# Altium schematic sheet CANFD.SchDoc
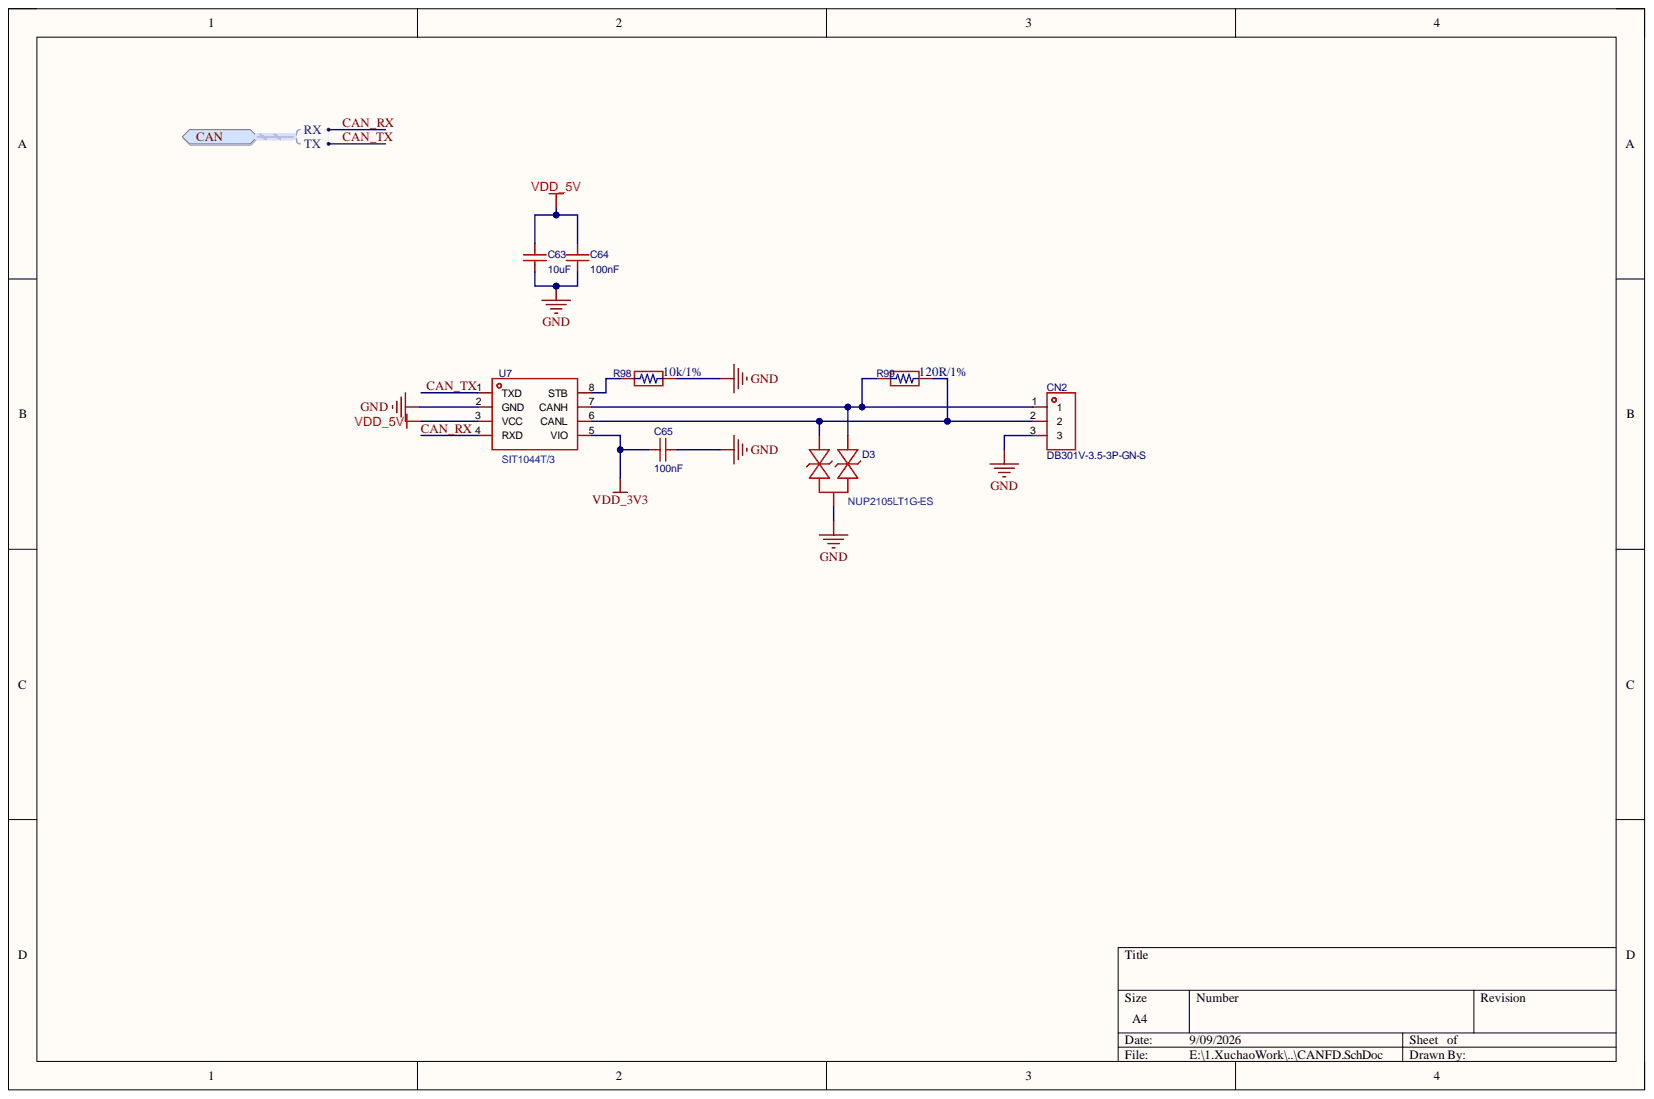
[diagram p0: CANFD.SchDoc]

## Parts
C63  10uF  [C0402]
C64  100nF  [C0402]
C65  100nF  [C0402]
CN2  DB301V-3.5-3P-GN-S  [CONN-TH_DB301V-3.5-3P-GN]
D3  NUP2105LT1G-ES  [SOT-23-3_L2.9-W1.3-P0.95-LS2.4-BR]
R98  10k/1%  [R0402]
R99  120R/1%  [R0603]
U7  SIT1044T/3  [SOP-8_L4.9-W3.9-P1.27-LS6.0-BL]

## Ports
CAN, CAN.RX, CAN.TX

## Nets
GND: C63.1 C64.1 C65.1 CN2.3 D3.3 R98.1 U7.2(GND)
VDD_3V3: C65.2 U7.5(VIO)
VDD_5V: C63.2 C64.2 U7.3(VCC)
CAN_RX: U7.4(RXD) ; port CAN
CAN_TX: U7.1(TXD) ; port CAN
NetCN2_1: CN2.1 D3.1 R99.2 U7.7(CANH)
NetCN2_2: CN2.2 D3.2 R99.1 U7.6(CANL)
NetR98_2: R98.2 U7.8(STB)

## Library data of the parts
C63-C65 = 0SMD_CAP: Symbol: CL10A106KP8NNNC; Component Kind: Standard; Library Name: CYKJ_LibPkg.IntLib
CN2 = CONN_DB301V-3.5-3P-GN-S: 结构:1x3P;间距:3.5mm;颜色:绿色;安装方式:直插;排数:1;每排PIN数:3;额定电流:7A;额定电压:300V;线规 - AWG:18~24;线规 - 平方毫米:0.5~1;工作温度:-40℃~+105℃;; Manufacturer: DORABO(地博电气); AddInBom: yes; Current Rating: 7A; ModelId: b68b144a19374becb19b2877333498c7聨0819f05c4eef4c71ace90d822a990e87; ModelTransform: 452.755,255.905,0,0,0,0,-0.005,5.906,-137.795; Number of PINs Per Row: 3; Number of Positions or Pins: 1x3P; Pitch: 3.5mm; Screw Specification: M2; Voltage Rating (Max): 300V; Wire Gauge - AWG: 18~24; Wire Gauge - mm2: 0.5~1; Color: 绿色; Component Kind: Standard; ConvertToPcb: yes; Datasheet: https://item.szlcsc.com/datasheet/DB301V-3.5-3P-GN-S/728966.html; Device: DB301V-3.5-3P-GN-S; FootprintName: CONN-TH_DB301V-3.5-3P-GN; JLCPCB Part Class: Extended Part; LCSC Part Name: 1x3P 3.5mm 直插 排数:1 每排P数:3; ManufacturerPart: DB301V-3.5-3P-GN-S; ModelName: CONN-TH_DB301V-3.5-3P-GN; Mounting Type: 直插; Number of Pins: 3P; Number of Rows: 1; Operating Temperature: -40℃~+105℃; Supplier: LCSC; Supplier Footprint: 插件,P=3.5mm; SupplierPart: C695630; Symbol: DB301V-3.5-3P-GN; Library Name: CYKJ_LibPkg.IntLib
D3 = NUP2105LT1G-ES: 静电和浪涌保护(TVS/ESD); Component Kind: Standard; Symbol: NUP2105LT1G-ES; Library Name: CYKJ_LibPkg.IntLib
R98 R99 = Resisteors: RES_SMD; Component Kind: Standard; Library Name: CYKJ_library.SchLib
U7 = SIT1044T/3: CAN收发器; Component Kind: Standard; Symbol: SIT1044T/3; Library Name: CYKJ_LibPkg.IntLib
